# Altium schematic sheet Robot_Mainboard_SCH.SchDoc(Robot_Mainboard_SCH)
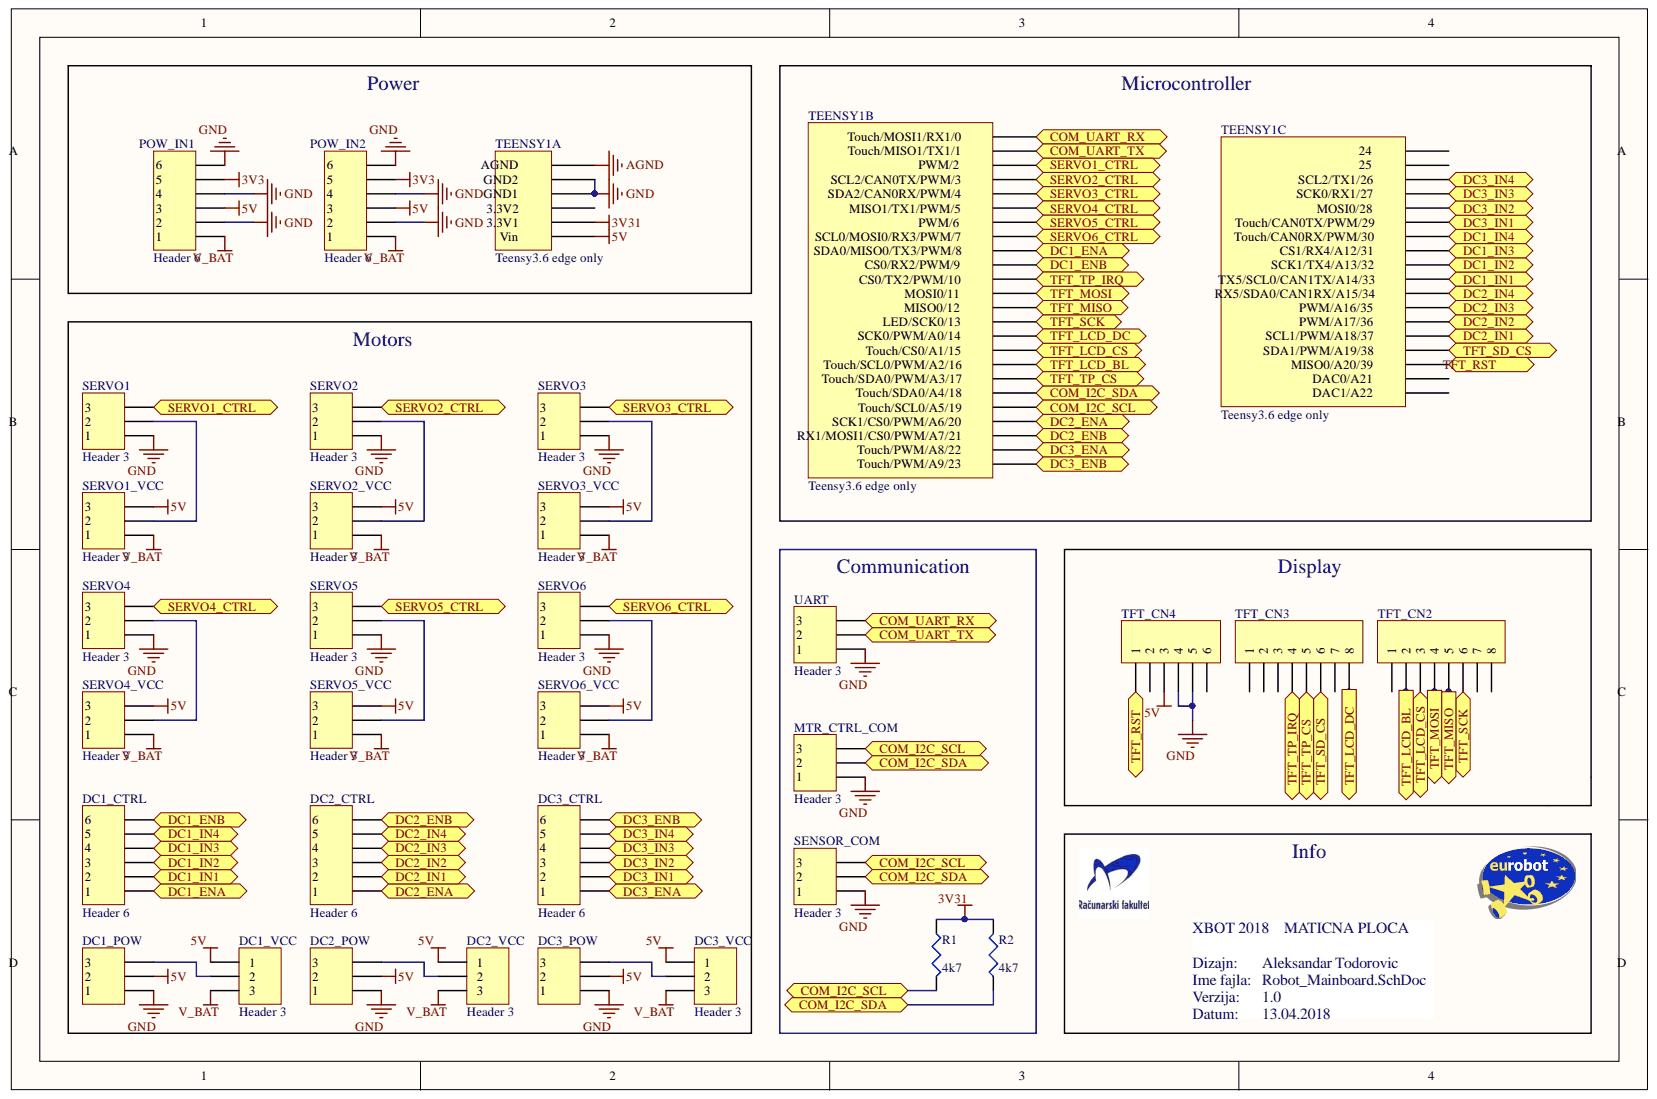
[diagram p0: Robot_Mainboard_SCH.SchDoc]

## Parts
DC1_CTRL  Header 6  [HDR1X6]
DC1_POW  Sistem klema 3  [Sistem klema 3]  (value not drawn)
DC1_VCC  Header 3  [HDR1X3]
DC2_CTRL  Header 6  [HDR1X6]
DC2_POW  Sistem klema 3  [Sistem klema 3]  (value not drawn)
DC2_VCC  Header 3  [HDR1X3]
DC3_CTRL  Header 6  [HDR1X6]
DC3_POW  Sistem klema 3  [Sistem klema 3]  (value not drawn)
DC3_VCC  Header 3  [HDR1X3]
MTR_CTRL_COM  Header 3  [HDR1X3]
POW_IN1  Header 6  [HDR1X6]
POW_IN2  Header 6  [HDR1X6]
R1  value 4k7  [C1206]  (hidden comment: Res3)
R2  value 4k7  [C1206]  (hidden comment: Res3)
SENSOR_COM  Header 3  [HDR1X3]
SERVO1  Header 3  [HDR1X3]
SERVO1_VCC  Header 3  [HDR1X3]
SERVO2  Header 3  [HDR1X3]
SERVO2_VCC  Header 3  [HDR1X3]
SERVO3  Header 3  [HDR1X3]
SERVO3_VCC  Header 3  [HDR1X3]
SERVO4  Header 3  [HDR1X3]
SERVO4_VCC  Header 3  [HDR1X3]
SERVO5  Header 3  [HDR1X3]
SERVO5_VCC  Header 3  [HDR1X3]
SERVO6  Header 3  [HDR1X3]
SERVO6_VCC  Header 3  [HDR1X3]
TEENSY1  Teensy3.6 edge only  [Teensy3.6-edgeonly]  (parts A,B,C)
TFT_CN2  Header 8  [HDR1X8]  (value not drawn)
TFT_CN3  Header 8  [HDR1X8]  (value not drawn)
TFT_CN4  Header 6  [HDR1X6]  (value not drawn)
UART  Header 3  [HDR1X3]

## Ports
COM_I2C_SCL, COM_I2C_SDA, COM_UART_RX, COM_UART_TX, DC1_ENA, DC1_ENB, DC1_IN1, DC1_IN2, DC1_IN3, DC1_IN4, DC2_ENA, DC2_ENB, DC2_IN1, DC2_IN2, DC2_IN3, DC2_IN4, DC3_ENA, DC3_ENB, DC3_IN1, DC3_IN2, DC3_IN3, DC3_IN4, SERVO1_CTRL, SERVO2_CTRL, SERVO3_CTRL, SERVO4_CTRL, SERVO5_CTRL, SERVO6_CTRL, TFT_LCD_BL, TFT_LCD_CS, TFT_LCD_DC, TFT_MISO, TFT_MOSI, TFT_RST, TFT_SCK, TFT_SD_CS, TFT_TP_CS, TFT_TP_IRQ

## Nets
3V3: POW_IN1.5 POW_IN2.5
3V31: R1.1 R2.2 TEENSY1.3.3V1
5V: DC1_POW.2 DC1_VCC.1 DC2_POW.2 DC2_VCC.1 DC3_POW.2 DC3_VCC.1 POW_IN1.3 POW_IN2.3 SERVO1_VCC.3 SERVO2_VCC.3 SERVO3_VCC.3 SERVO4_VCC.3 SERVO5_VCC.3 SERVO6_VCC.3 TEENSY1.VIN TFT_CN4.3
AGND: TEENSY1.AGND
GND: DC1_POW.1 DC2_POW.1 DC3_POW.1 MTR_CTRL_COM.1 POW_IN1.2 POW_IN1.4 POW_IN1.6 POW_IN2.2 POW_IN2.4 POW_IN2.6 SENSOR_COM.1 SERVO1.1 SERVO2.1 SERVO3.1 SERVO4.1 SERVO5.1 SERVO6.1 TEENSY1.GND1 TEENSY1.GND2 TFT_CN4.4 TFT_CN4.5 UART.1
V_BAT: DC1_VCC.3 DC2_VCC.3 DC3_VCC.3 POW_IN1.1 POW_IN2.1 SERVO1_VCC.1 SERVO2_VCC.1 SERVO3_VCC.1 SERVO4_VCC.1 SERVO5_VCC.1 SERVO6_VCC.1
COM_I2C_SCL: MTR_CTRL_COM.3 R1.2 SENSOR_COM.3 TEENSY1.19 ; port COM_I2C_SCL
COM_I2C_SDA: MTR_CTRL_COM.2 R2.1 SENSOR_COM.2 TEENSY1.18 ; port COM_I2C_SDA
COM_UART_RX: TEENSY1.0 UART.3 ; port COM_UART_RX
COM_UART_TX: TEENSY1.1 UART.2 ; port COM_UART_TX
DC1_ENA: DC1_CTRL.1 TEENSY1.8 ; port DC1_ENA
DC1_ENB: DC1_CTRL.6 TEENSY1.9 ; port DC1_ENB
DC1_IN1: DC1_CTRL.2 TEENSY1.33 ; port DC1_IN1
DC1_IN2: DC1_CTRL.3 TEENSY1.32 ; port DC1_IN2
DC1_IN3: DC1_CTRL.4 TEENSY1.31 ; port DC1_IN3
DC1_IN4: DC1_CTRL.5 TEENSY1.30 ; port DC1_IN4
DC2_ENA: DC2_CTRL.1 TEENSY1.20 ; port DC2_ENA
DC2_ENB: DC2_CTRL.6 TEENSY1.21 ; port DC2_ENB
DC2_IN1: DC2_CTRL.2 TEENSY1.37 ; port DC2_IN1
DC2_IN2: DC2_CTRL.3 TEENSY1.36 ; port DC2_IN2
DC2_IN3: DC2_CTRL.4 TEENSY1.35 ; port DC2_IN3
DC2_IN4: DC2_CTRL.5 TEENSY1.34 ; port DC2_IN4
DC3_ENA: DC3_CTRL.1 TEENSY1.22 ; port DC3_ENA
DC3_ENB: DC3_CTRL.6 TEENSY1.23 ; port DC3_ENB
DC3_IN1: DC3_CTRL.2 TEENSY1.29 ; port DC3_IN1
DC3_IN2: DC3_CTRL.3 TEENSY1.28 ; port DC3_IN2
DC3_IN3: DC3_CTRL.4 TEENSY1.27 ; port DC3_IN3
DC3_IN4: DC3_CTRL.5 TEENSY1.26 ; port DC3_IN4
SERVO1_CTRL: SERVO1.3 TEENSY1.2 ; port SERVO1_CTRL
SERVO2_CTRL: SERVO2.3 TEENSY1.3 ; port SERVO2_CTRL
SERVO3_CTRL: SERVO3.3 TEENSY1.4 ; port SERVO3_CTRL
SERVO4_CTRL: SERVO4.3 TEENSY1.5 ; port SERVO4_CTRL
SERVO5_CTRL: SERVO5.3 TEENSY1.6 ; port SERVO5_CTRL
SERVO6_CTRL: SERVO6.3 TEENSY1.7 ; port SERVO6_CTRL
TFT_LCD_BL: TEENSY1.16 TFT_CN2.2 ; port TFT_LCD_BL
TFT_LCD_CS: TEENSY1.15 TFT_CN2.3 ; port TFT_LCD_CS
TFT_LCD_DC: TEENSY1.14 TFT_CN3.8 ; port TFT_LCD_DC
TFT_MISO: TEENSY1.12 TFT_CN2.5 ; port TFT_MISO
TFT_MOSI: TEENSY1.11 TFT_CN2.4 ; port TFT_MOSI
TFT_RST: TEENSY1.39 TFT_CN4.1 ; port TFT_RST
TFT_SCK: TEENSY1.13 TFT_CN2.6 ; port TFT_SCK
TFT_SD_CS: TEENSY1.38 TFT_CN3.6 ; port TFT_SD_CS
TFT_TP_CS: TEENSY1.17 TFT_CN3.5 ; port TFT_TP_CS
TFT_TP_IRQ: TEENSY1.10 TFT_CN3.4 ; port TFT_TP_IRQ
NetDC1_POW_3: DC1_POW.3 DC1_VCC.2
NetDC2_POW_3: DC2_POW.3 DC2_VCC.2
NetDC3_POW_3: DC3_POW.3 DC3_VCC.2
NetSERVO1_2: SERVO1.2 SERVO1_VCC.2
NetSERVO2_2: SERVO2.2 SERVO2_VCC.2
NetSERVO3_2: SERVO3.2 SERVO3_VCC.2
NetSERVO4_2: SERVO4.2 SERVO4_VCC.2
NetSERVO5_2: SERVO5.2 SERVO5_VCC.2
NetSERVO6_2: SERVO6.2 SERVO6_VCC.2
N00006:

Not connected: TEENSY1 3.3V2 24 25 A21 A22; TFT_CN2 1 7 8; TFT_CN3 1 2 3 7; TFT_CN4 2 6

## Library data of the parts
DC1_CTRL DC2_CTRL DC3_CTRL POW_IN1 POW_IN2 TFT_CN4 = Header 6: Header, 6-Pin; Component Kind: Standard; LatestRevisionDate: 17-Jul-2002; LatestRevisionNote: Re-released for DXP Platform.; Library Name: Miscellaneous Connectors.IntLib; Signal Integrity: Connector; Publisher: Altium Limited
DC1_VCC DC2_VCC DC3_VCC MTR_CTRL_COM SENSOR_COM SERVO1 SERVO1_VCC SERVO2 SERVO2_VCC SERVO3 SERVO3_VCC SERVO4 SERVO4_VCC SERVO5 SERVO5_VCC SERVO6 SERVO6_VCC UART = Header 3: Header, 3-Pin; Component Kind: Standard; LatestRevisionDate: 17-Jul-2002; LatestRevisionNote: Re-released for DXP Platform.; Library Name: Miscellaneous Connectors.IntLib; Signal Integrity: Connector; Publisher: Altium Limited
R1 R2 = Res3: Resistor; Component Kind: Standard; LatestRevisionDate: 29-May-2009; LatestRevisionNote: IPC-7351 Footprint Added.; Library Name: Miscellaneous Devices.SchLib; PackageDescription: Chip Resistor; PackageReference: J1-0603; Published: 8-Jun-2000; Signal Integrity: Res; Simulation: RESISTOR; Publisher: Altium Limited
TFT_CN2 TFT_CN3 = Header 8: Header, 8-Pin; Component Kind: Standard; LatestRevisionDate: 17-Jul-2002; LatestRevisionNote: Re-released for DXP Platform.; Library Name: Miscellaneous Connectors.IntLib; Signal Integrity: Connector; Publisher: Altium Limited
DC1_POW DC2_POW DC3_POW = Sistem klema 3: Component Kind: Standard; Library Name: LaserParts.SchLib
TEENSY1 = Teensy3.6 edge only: Component Kind: Standard; Library Name: teensy3.6.SchLib
